# Altium schematic sheet 05_CT_PT.SchDoc(05_CT_PT)
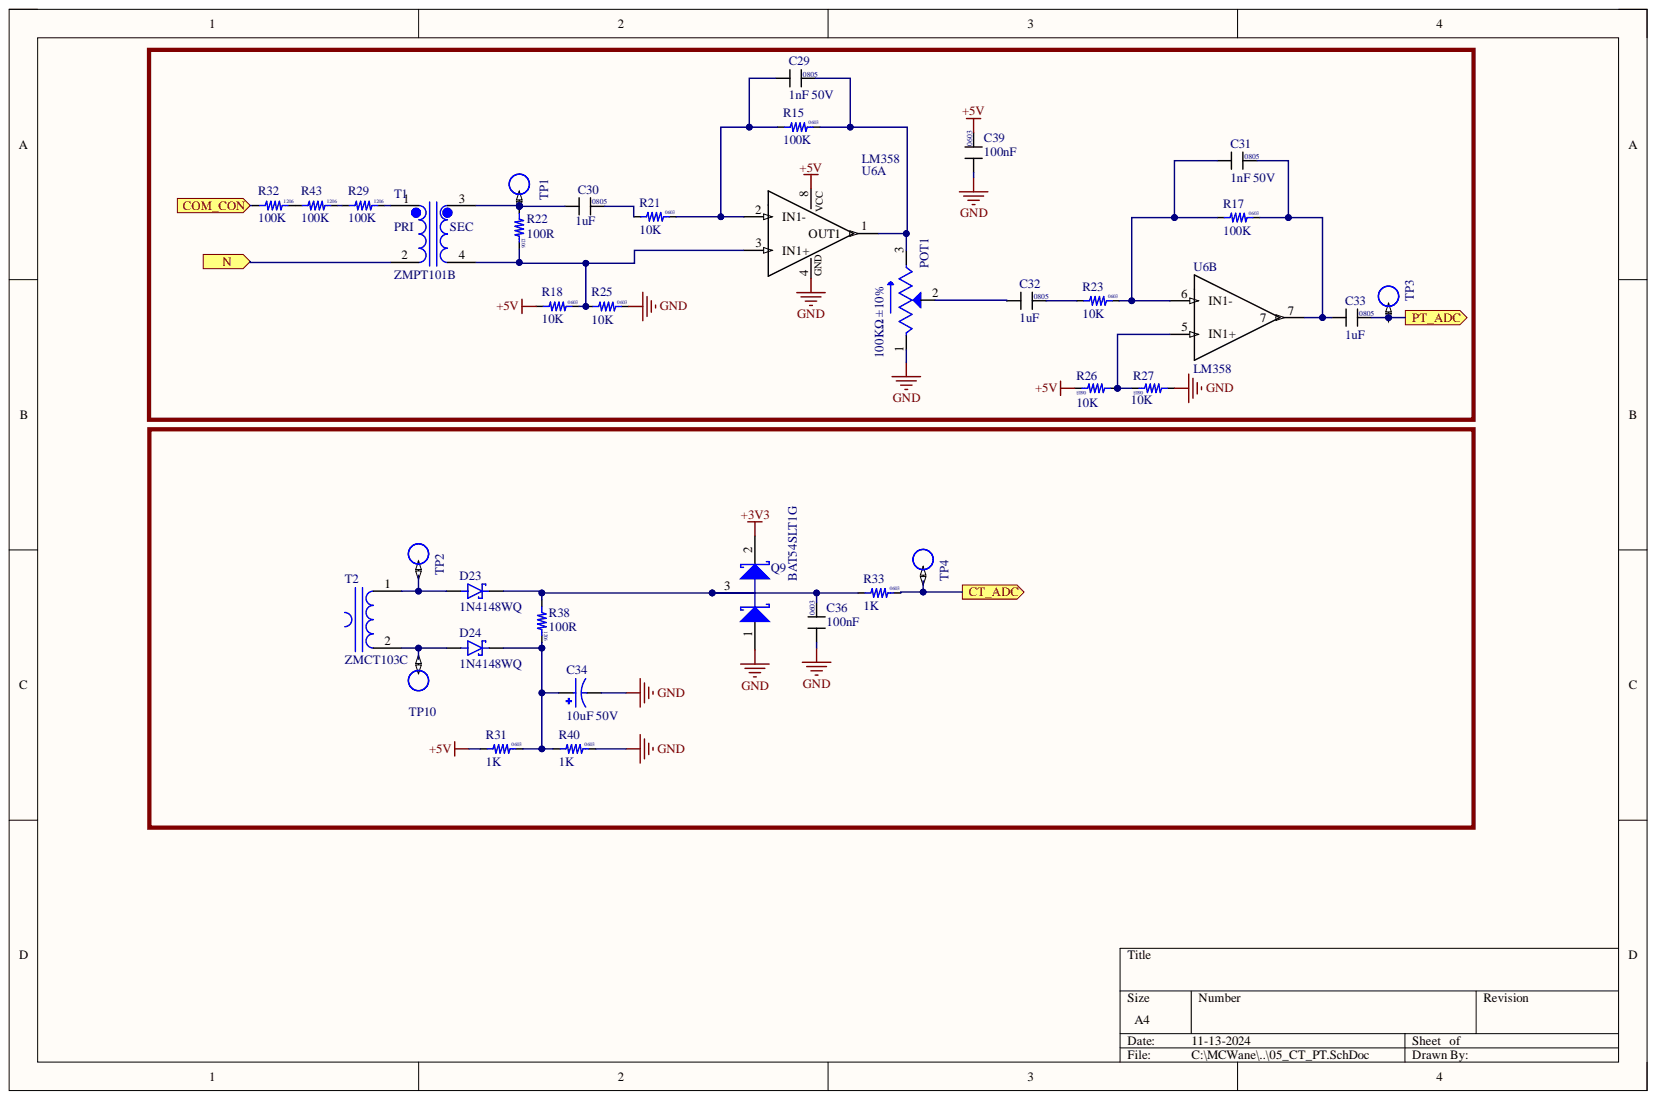
[diagram p0: 05_CT_PT.SchDoc]

## Parts
C29  1nF 50V  Package: 0805  [CAP_0805]
C30  1uF  Package: 0805  [CAP_0805]
C31  1nF 50V  Package: 0805  [CAP_0805]
C32  1uF  Package: 0805  [CAP_0805]
C33  1uF  Package: 0805  [CAP_0805]
C34  10uF 50V  [cap_el_d6.3_h11_pitch2.5_w0.5]
C36  100nF  Package: 0603  [CAP_0603]
C39  100nF  Package: 0603  [CAP_0603]
D23  1N4148WQ  [SOD123]
D24  1N4148WQ  [SOD123]
POT1  100KΩ ±10%  [POT_3296-W]
Q9  BAT54SLT1G  [SOT23]
R15  100K  Package: 0603  [RES_0603]
R17  100K  Package: 0603  [RES_0603]
R18  10K  Package: 0603  [RES_0603]
R21  10K  Package: 0603  [RES_0603]
R22  100R  Package: 1206  [RES_1206]
R23  10K  Package: 0603  [RES_0603]
R25  10K  Package: 0603  [RES_0603]
R26  10K  Package: 0603  [RES_0603]
R27  10K  Package: 0603  [RES_0603]
R29  100K  Package: 1206  [RES_1206]
R31  1K  Package: 0603  [RES_0603]
R32  100K  Package: 1206  [RES_1206]
R33  1K  Package: 0603  [RES_0603]
R38  100R  Package: 1206  [RES_1206]
R40  1K  Package: 0603  [RES_0603]
R43  100K  Package: 1206  [RES_1206]
T1  ZMPT101B  [XFMR_ZMPT101B]
T2  ZMCT103C  [ZMCT103C]
TP1  TP  [TEST_POINT_SMD]
TP2  TP  [TEST_POINT_SMD]
TP3  TP  [TEST_POINT_SMD]
TP4  TP  [TEST_POINT_SMD]
TP10  TP  [TEST_POINT_SMD]
U6  LM358  [SOIC127P600X175-8N]  (parts A,B)

## Ports
COM_CON, CT_ADC, N, PT_ADC

## Nets
+3V3: Q9.2
+5V: C39.2 R18.1 R26.2 R31.1 U6.8
GND: C34.2 C36.1 C39.1 POT1.1 Q9.1 R25.2 R27.1 R40.2 U6.4
COM_CON: R32.1 ; port COM_CON
CT_ADC: R33.2 TP4.1 ; port CT_ADC
N: T1.2 ; port N
PT_ADC: C33.2 TP3.1 ; port PT_ADC
NetC29_1: C29.1 R15.1 R21.2 U6.2(IN1-)
NetC29_2: C29.2 POT1.3 R15.2 U6.1(OUT1)
NetC30_1: C30.1 R22.1 T1.3 TP1.1
NetC30_2: C30.2 R21.1
NetC31_1: C31.1 R17.1 R23.2 U6.6(IN1-)
NetC31_2: C31.2 C33.1 R17.2 U6.7
NetC32_1: C32.1 POT1.2
NetC32_2: C32.2 R23.1
NetC34_1: C34.1 D24.C R31.2 R38.2 R40.1
NetC36_2: C36.2 D23.C Q9.3 R33.1 R38.1
NetD23_A: D23.A T2.1 TP2.1
NetD24_A: D24.A T2.2 TP10.1
NetR18_2: R18.2 R22.2 R25.1 T1.4 U6.3(IN1+)
NetR26_1: R26.1 R27.2 U6.5(IN1+)
NetR29_1: R29.1 R43.2
NetR29_2: R29.2 T1.1
NetR32_2: R32.2 R43.1

## Library data of the parts
C29 C31 = C0805-1nF 50V-MLCC: SMD Multilayer Ceramic Capacitor, 1nF, 50 V, 0805 [2012 Metric], ± 10%, X7R, -55 °C; Manufacturer Part Number: CC0805KRX7R9BB102; Brand: YAGEO; Marking: 102; Component: Capacitor; Component Kind: Standard; Library Name: Passive_Components.SchLib; Supplier: ELEMENT 14
C30 C32 C33 = C0805-1uF 50V-MLCC: SMD Multilayer Ceramic Capacitor, 1 µF, 50 V, 0805 [2012 Metric], ± 10%, X7R, -55 °C; Manufacturer Part Number: CC0805KKX7R9BB105; Brand: YAGEO; Marking: 105; Component: Capacitor; Component Kind: Standard; Library Name: Passive_Components.SchLib; Supplier: ELEMENT 14
C34 = CAP_TH_10uF_50V: ALUMINUM ELECTROLYTIC CAPACITOR 10UF, 50V, 20%, RADIAL; Manufacturer Part Number: ECE-A1HKS100; Brand: Panasonic; Component: Capacitor; Component Kind: Standard; Library Name: Passive_Components.SchLib; Package: 5X11X2.5mm(DXHXP); Supplier: Mouser Electronics
C36 C39 = C0603-0.1uF 50V-MLCC: SMD Multilayer Ceramic Capacitor, 0.1 µF, 50 V, 0603 [1608 Metric], ± 10%, X7R; Manufacturer Part Number: CC0603KRX7R9BB104; Brand: YAGEO; Marking: 104; Component: Capacitor; Component Kind: Standard; LCSC Part: C14663; Library Name: Passive_Components.SchLib; Supplier: ELEMENT 14
D23 D24 = 1N4148WQ: Diode 100 V 300mA Surface Mount SOD-123; Manufacturer Part Number: 1N4148WQ-13-F; Brand: DIODES INC; Component Kind: Standard; LCSC Part: C3151708; Library Name: Active_Components.SchLib; Package: SOD-123
POT1 = POT 3296W-1-104: 100 kOhms 0.5W, 1/2W PC Pins Through Hole Trimmer Potentiometer Cermet 25.0 Turn Top Adjustment; Brand: Bourns; Component Kind: Standard; Library Name: Passive_Components.SchLib; Manufacturer Part Number: 3296W-1-104; Package: Rectangular - 0.375" x 0.190" Face (9.53mm x 4.83mm); Supplier: Mouser Electronics
Q9 = BAT54SLT1G: Diode, Schottky, 30 V, 0.2 A, SOT-23; Brand: onsemi; Component Kind: Standard; Library Name: Active_Components.SchLib; Manufacturer Part Number: BAT54SLT1G; Package: SOT23-3
R15 R17 = R0603-100K-1%: SMD Chip Resistor, 100 kohm, ± 1%, 100 mW, 0603 [1608 Metric], Thick Film, General Purpose; Manufacturer Part Number: RC0603FR-07100KL; Brand: YAGEO; Marking: 1003; Component: Resistor; Component Kind: Standard; LCSC Part: C14675; Library Name: Passive_Components.SchLib; Supplier: ELEMENT 14
R18 R21 R23 R25-R27 = R0603-10K-1%: SMD Chip Resistor, 10 kohm, ± 1%, 100 mW, 0603 [1608 Metric], Thick Film, General Purpose; Manufacturer Part Number: RC0603FR-0710KL; Brand: YAGEO; Marking: 1002; Component: Resistor; Component Kind: Standard; LCSC Part: C98220; Library Name: Passive_Components.SchLib; Supplier: ELEMENT 14
R22 R38 = R1206-100E-1%: SMD Chip Resistor, 100 ohm, ± 1%, 250 mW, 1206 [3216 Metric], Thick Film, General Purpose; Manufacturer Part Number: RC1206FR-07100RL; Brand: YAGEO; Component: Resistor; Component Kind: Standard; Library Name: Passive_Components.SchLib; Marking: 100R; Supplier: ELEMENT 14
R29 R32 R43 = R1206-100K-1%: SMD Chip Resistor, 100 kohm, ± 1%, 250 mW, 1206 [3216 Metric], Thick Film, General Purpose; Manufacturer Part Number: RC1206FR-07100KL; Brand: YAGEO; Marking: 1003; Component: Resistor; Component Kind: Standard; Library Name: Passive_Components.SchLib; Supplier: ELEMENT 14
R31 R33 R40 = R0603-1K-1%: SMD Chip Resistor, 1 kohm, ± 1%, 100 mW, 0603 [1608 Metric], Thick Film, General Purpose; Manufacturer Part Number: RC0603FR-071KL; Brand: YAGEO; Marking: 1001; Component: Resistor; Component Kind: Standard; LCSC Part: C109318; Library Name: Passive_Components.SchLib; Supplier: ELEMENT 14
T1 = ZMPT101B: Current-type Voltage Transformer; Brand: Qingxian Zeming Langxi Electronic; Component Kind: Standard; Library Name: Active_Components.SchLib; Manufacturer Part Number: ZMPT101B; Package: TH
T2 = ZMCT103C: Plugin Current Transformers; Manufacturer Part Number: ZMPT101B; Brand: Qingxian Zeming Langxi Electronic; Component Kind: Standard; Library Name: Active_Components.SchLib; Package: TH
TP1-TP4 TP10 = TEST_POINT_SMD: Component Kind: Standard; Library Name: CONNECTOR.SchLib
U6 = LM358MX/NOPB: Operational Amplifier, 2 Amplifier, 1 MHz, 0.1 V/µs, 3V to 32V, ± 1.5V to ± 16V, SOIC, 8 Pins; Brand: TEXAS INSTRUMENTS; Component Kind: Standard; Library Name: Active_Components.SchLib; Manufacturer Part Number: LM358MX/NOPB; Package: SOIC8
